# Altium schematic sheet Samyak_Sensors.SchDoc(Samyak_Sensors)
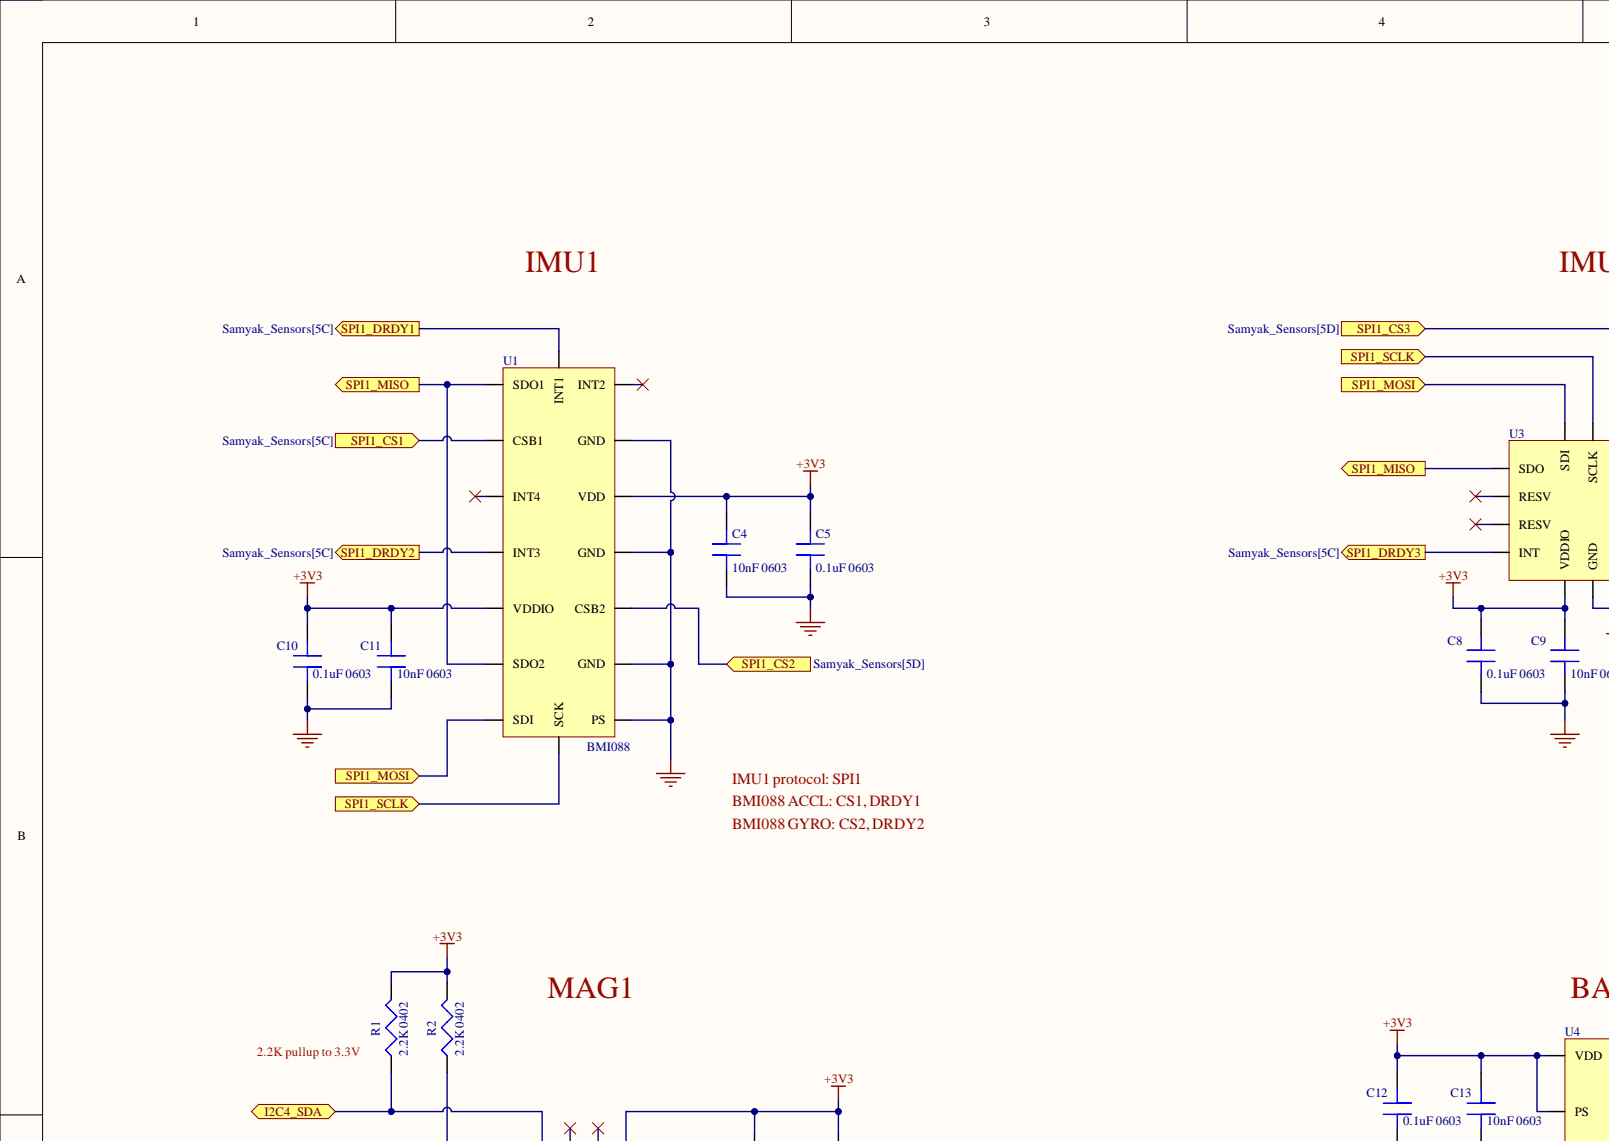
[diagram p0: Samyak_Sensors.SchDoc, top left]
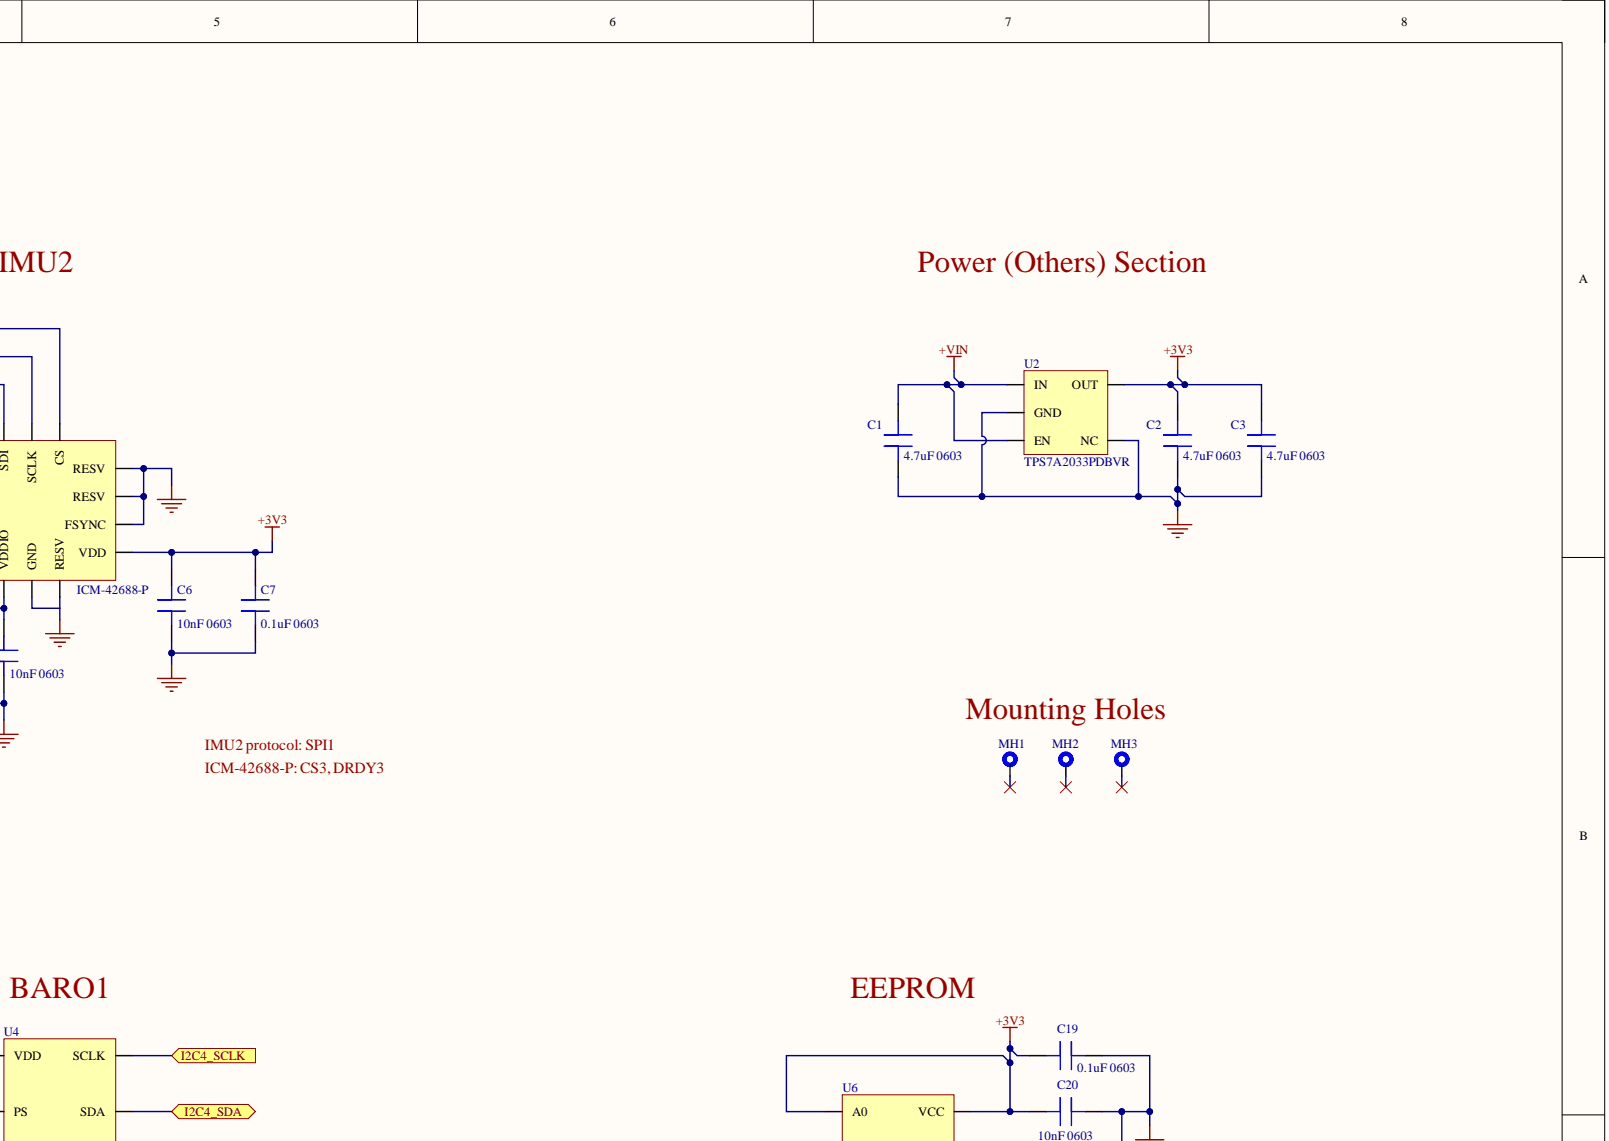
[diagram p1: Samyak_Sensors.SchDoc, top right]
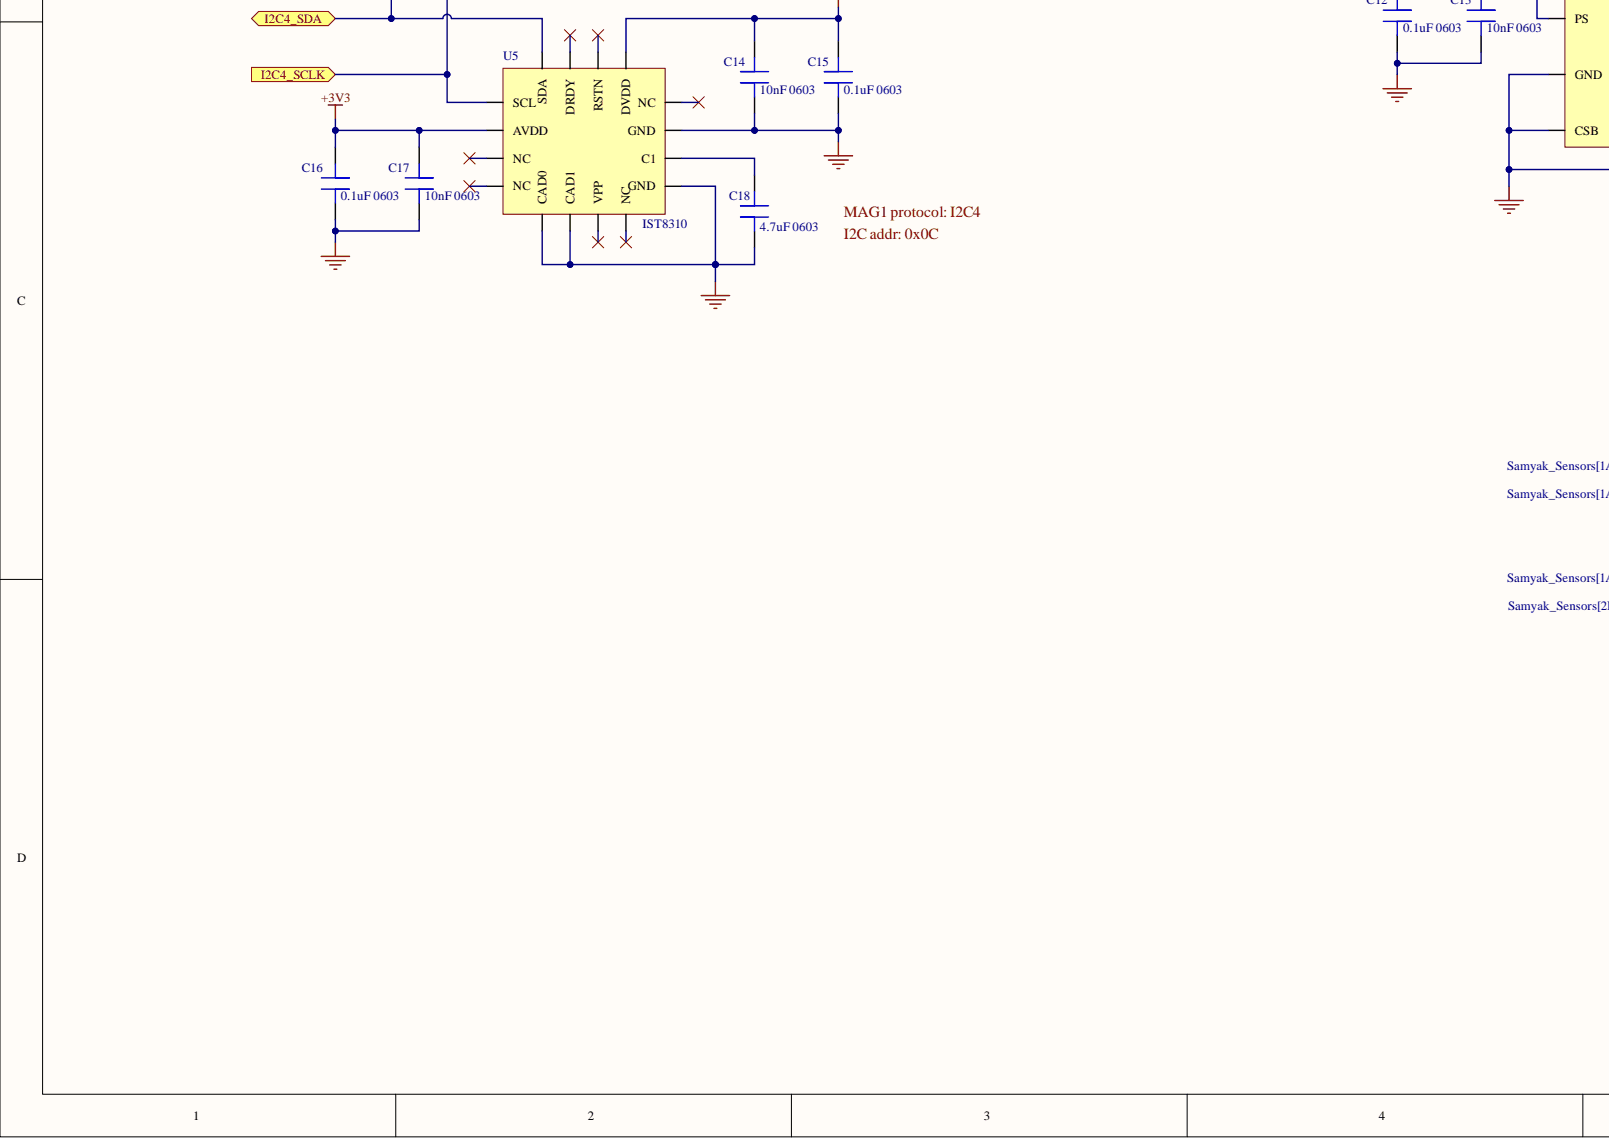
[diagram p2: Samyak_Sensors.SchDoc, bottom left]
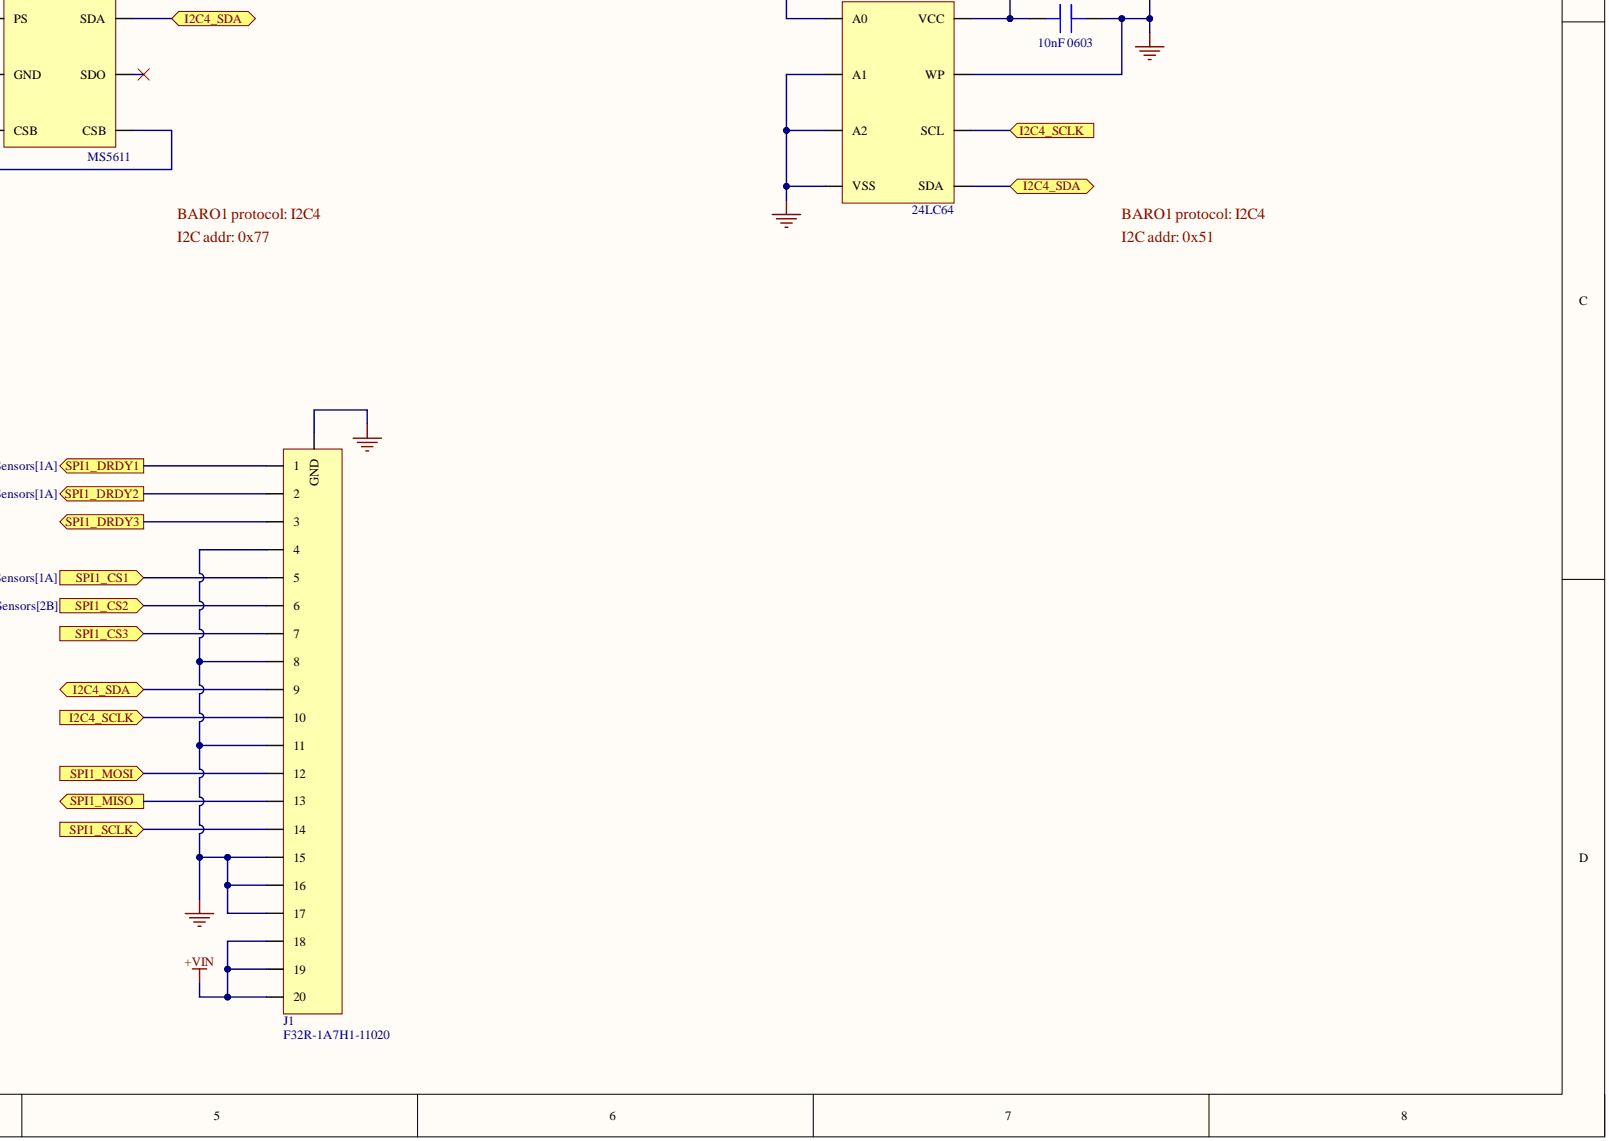
[diagram p3: Samyak_Sensors.SchDoc, bottom right]

## Parts
C1  4.7uF 0603  [GRM18]
C2  4.7uF 0603  [GRM18]
C3  4.7uF 0603  [GRM18]
C4  10nF 0603  Capacitance: 10nF  [C0603]
C5  0.1uF 0603  Case Code (Imperial): 0603  [C0603]
C6  10nF 0603  Capacitance: 10nF  [C0603]
C7  0.1uF 0603  Case Code (Imperial): 0603  [C0603]
C8  0.1uF 0603  [C0603]
C9  10nF 0603  Capacitance: 10nF  Case Code (Imperial): 0603  [C0603]
C10  0.1uF 0603  [C0603]
C11  10nF 0603  Capacitance: 10nF  Case Code (Imperial): 0603  [C0603]
C12  0.1uF 0603  [C0603]
C13  10nF 0603  Capacitance: 10nF  Case Code (Imperial): 0603  [C0603]
C14  10nF 0603  Capacitance: 10nF  [C0603]
C15  0.1uF 0603  Case Code (Imperial): 0603  [C0603]
C16  0.1uF 0603  [C0603]
C17  10nF 0603  Capacitance: 10nF  Case Code (Imperial): 0603  [C0603]
C18  4.7uF 0603  [GRM18]
C19  0.1uF 0603  Case Code (Imperial): 0603  [C0603]
C20  10nF 0603  Capacitance: 10nF  Case Code (Imperial): 0603  [C0603]
J1  F32R-1A7H1-11020  [F32R-1A7H1-11020]
MH1  M2 Hole NPTH  [M2_hole_NPTH]  (value not drawn)
MH2  M2 Hole NPTH  [M2_hole_NPTH]  (value not drawn)
MH3  M2 Hole NPTH  [M2_hole_NPTH]  (value not drawn)
R1  2.2K 0402  Case Code (Imperial): 0402  [R0402]
R2  2.2K 0402  Case Code (Imperial): 0402  [R0402]
U1  BMI088  [BMI088]
U2  TPS7A2033PDBVR  [TPS7A20]
U3  ICM-42688-P  [ICM-42688-P]
U4  MS5611  [MS5611]
U5  IST8310  [IST8310]
U6  24LC64  [24LC64]

## Ports
I2C4_SCLK, I2C4_SDA, SPI1_CS1, SPI1_CS2, SPI1_CS3, SPI1_DRDY1, SPI1_DRDY2, SPI1_DRDY3, SPI1_MISO, SPI1_MOSI, SPI1_SCLK

## Nets
+3V3: C2.2 C3.2 C4.2 C5.2 C6.2 C7.2 C8.2 C9.2 C10.2 C11.2 C12.2 C13.2 C14.2 C15.2 C16.2 C17.2 C19.2 C20.2 R1.2 R2.2 U1.3(VDD) U1.11(VDDIO) U2.5(OUT) U3.5(VDDIO) U3.8(VDD) U4.1(VDD) U4.2(PS) U5.2(AVDD) U5.13(DVDD) U6.1(A0) U6.8(VCC)
+VIN: C1.2 J1.18 J1.19 J1.20 U2.1(IN) U2.3(EN)
GND: C1.1 C2.1 C3.1 C4.1 C5.1 C6.1 C7.1 C8.1 C9.1 C10.1 C11.1 C12.1 C13.1 C14.1 C15.1 C16.1 C17.1 C18.1 C19.1 C20.1 J1.4 J1.8 J1.11 J1.15 J1.16 J1.17 J1.21(GND) U1.2(GND) U1.4(GND) U1.6(GND) U1.7(PS) U2.2(GND) U2.4(NC) U3.6(GND) U3.7(RESV) U3.9(FSYNC) U3.10(RESV) U3.11(RESV) U4.3(GND) U4.4(CSB) U4.5(CSB) U5.5(CAD0) U5.6(CAD1) U5.9(GND) U5.11(GND) U6.2(A1) U6.3(A2) U6.4(VSS) U6.7(WP)
I2C4_SCLK: J1.10 R2.1 U4.8(SCLK) U5.1(SCL) U6.6(SCL) ; port I2C4_SCLK
I2C4_SDA: J1.9 R1.1 U4.7(SDA) U5.16(SDA) U6.5(SDA) ; port I2C4_SDA
SPI1_CS1: J1.5 U1.14(CSB1) ; port SPI1_CS1
SPI1_CS2: J1.6 U1.5(CSB2) ; port SPI1_CS2
SPI1_CS3: J1.7 U3.12(CS) ; port SPI1_CS3
SPI1_DRDY1: J1.1 U1.16(INT1) ; port SPI1_DRDY1
SPI1_DRDY2: J1.2 U1.12(INT3) ; port SPI1_DRDY2
SPI1_DRDY3: J1.3 U3.4(INT) ; port SPI1_DRDY3
SPI1_MISO: J1.13 U1.10(SDO2) U1.15(SDO1) U3.1(SDO) ; port SPI1_MISO
SPI1_MOSI: J1.12 U1.9(SDI) U3.14(SDI) ; port SPI1_MOSI
SPI1_SCLK: J1.14 U1.8(SCK) U3.13(SCLK) ; port SPI1_SCLK
NetC18_2: C18.2 U5.10(C1)

Not connected: MH1 1; MH2 1; MH3 1; U1 1(INT2) 13(INT4); U3 2(RESV) 3(RESV); U4 6(SDO); U5 3(NC) 4(NC) 7(VPP) 8(NC) 12(NC) 14(RSTN) 15(DRDY)

## Library data of the parts
C1-C3 C18 = GRM188Z71C475KE21J: Multi-Layer Ceramic Capacitor 4.7uF 16VDC X7R 10% 0603 Paper T/R; Manufacturer: Murata; Category: Ceramic Capacitors; Component Kind: Standard; Library Name: Components.IntLib; Manufacturer Part Number: GRM188Z71C475KE21J; Pricing 1: 1=0.32000, 10=0.22200, 50=0.22200, 100=0.12600, 1000=0.07800, 10000=0.05600 (USD); RoHS: Yes; RoHS Compliant: Yes; Stock 1: 56562; Supplier 1: Mouser; Supplier Part Number 1: 81-GRM188Z71C475KE1J
C4 C6 C9 C11 C13 C14 C17 C20 = C0603C103K5RACTU: 10000pF ±10% 50V Ceramic Capacitor X7R 0603 (1608 Metric); Manufacturer: KEMET; Dissipation Factor: 2.5%; Case Code (Metric): 1608; Case/Package: 0603; Category: Ceramic Capacitors; Component Kind: Standard; ComponentLink1Description: https://datasheet.ciiva.com/7823/1732718-7823585.pdf?src-supplier=Element14; ComponentLink1URL: https://datasheet.ciiva.com/7823/1732718-7823585.pdf?src-supplier=Element14; ComponentLink2Description: https://datasheet.ciiva.com/5904/kem-c1002-x7r-smd-5904645.pdf?src-supplier=Farnell; ComponentLink2URL: https://datasheet.ciiva.com/5904/kem-c1002-x7r-smd-5904645.pdf?src-supplier=Farnell; ComponentLink3Description: https://datasheet.ciiva.com/18192/getdatasheetpartid-308803-18192662.pdf?src-supplier=Verical; ComponentLink3URL: https://datasheet.ciiva.com/18192/getdatasheetpartid-308803-18192662.pdf?src-supplier=Verical; ComponentLink4Description: https://datasheet.ciiva.com/39122/kem-c1002-x7r-smd-39122903.pdf?src-supplier=Onlinecomponents.com; ComponentLink4URL: https://datasheet.ciiva.com/39122/kem-c1002-x7r-smd-39122903.pdf?src-supplier=Onlinecomponents.com; ComponentLink5Description: https://datasheet.ciiva.com/7823/kem-c1002-x7r-smd-7823976.pdf?src-supplier=Element14; ComponentLink5URL: https://datasheet.ciiva.com/7823/kem-c1002-x7r-smd-7823976.pdf?src-supplier=Element14; ComponentLink6Description: https://datasheet.ciiva.com/7823/kem-c1002-x7r-smd-7823977.pdf?src-supplier=Element14; ComponentLink6URL: https://datasheet.ciiva.com/7823/kem-c1002-x7r-smd-7823977.pdf?src-supplier=Element14; ComponentLink7Description: https://datasheet.ciiva.com/7823/1918693-7823978.pdf?src-supplier=Element14; ComponentLink7URL: https://datasheet.ciiva.com/7823/1918693-7823978.pdf?src-supplier=Element14; ComponentLink8Description: https://datasheet.ciiva.com/14585/70095383-14585450.pdf?src-supplier=Allied+Electronics; ComponentLink8URL: https://datasheet.ciiva.com/14585/70095383-14585450.pdf?src-supplier=Allied+Electronics; ComponentLink9Description: https://datasheet.ciiva.com/18101/getdatasheetpartid-58468-18101898.pdf?src-supplier=Verical; ComponentLink9URL: https://datasheet.ciiva.com/18101/getdatasheetpartid-58468-18101898.pdf?src-supplier=Verical; ComponentLink10Description: https://datasheet.ciiva.com/5416/kem-c1002-x7r-smd-5416028.pdf?src-supplier=Mouser; ComponentLink10URL: https://datasheet.ciiva.com/5416/kem-c1002-x7r-smd-5416028.pdf?src-supplier=Mouser; ComponentLink11Description: https://datasheet.ciiva.com/28645/1851736-28645598.pdf?src-supplier=Element14; ComponentLink11URL: https://datasheet.ciiva.com/28645/1851736-28645598.pdf?src-supplier=Element14; Contact Plating: Tin,Matte; Depth: 0.8mm; Dielectric: X7R; Dielectric Material: Ceramic; Height: 0.8mm; Lead Pitch: 0.7mm; Length: 1.6mm; Library Name: Components.IntLib; Manufacturer Part Number: C0603C103K5RACTU; Max Operating Temperature: 125°C; Min Operating Temperature: -55°C; Mount: Surface Mount,PCB; Number of Pins: 2; Package Quantity: 4000; Packaging: Tape and Reel; Pricing 1: 1=0.10000, 10=0.07000, 50=0.03820, 100=0.03130, 500=0.02234, 1000=0.01755 (USD); Radiation Hardening: No; REACH SVHC: No SVHC; RoHS: Yes; Stock 1: 1389959; Supplier 1: Digi-Key; Supplier Part Number 1: 399-1091-1-ND; Termination: SMD/SMT; Thickness: 0.034inch; Tolerance: 10%; Voltage: 50V; Voltage Rating: 50V; Voltage Rating (DC): 50V; Width: 0.8mm
  C4 C6 C14: Case Code (Imperial): 0603
C5 C7 C8 C10 C12 C15 C16 C19 = C0603C104K5RACTU: 0.1µF ±10% 50V Ceramic Capacitor X7R 0603 (1608 Metric); Manufacturer: KEMET; Capacitance: 100nF; Dissipation Factor: 2.5%; Case Code (Metric): 1608; Case/Package: 0603; Category: Ceramic Capacitors; Component Kind: Standard; ComponentLink1Description: https://datasheet.ciiva.com/7823/kem-c1002-x7r-smd-7823591.pdf?src-supplier=Element14; ComponentLink1URL: https://datasheet.ciiva.com/7823/kem-c1002-x7r-smd-7823591.pdf?src-supplier=Element14; ComponentLink2Description: https://datasheet.ciiva.com/14602/70095383-14602583.pdf?src-supplier=Allied+Electronics; ComponentLink2URL: https://datasheet.ciiva.com/14602/70095383-14602583.pdf?src-supplier=Allied+Electronics; ComponentLink3Description: https://datasheet.ciiva.com/5999/kem-c1002-x7r-smd-5999400.pdf?src-supplier=Farnell; ComponentLink3URL: https://datasheet.ciiva.com/5999/kem-c1002-x7r-smd-5999400.pdf?src-supplier=Farnell; ComponentLink4Description: https://datasheet.ciiva.com/7823/kem-c1002-x7r-smd-7823592.pdf?src-supplier=Element14; ComponentLink4URL: https://datasheet.ciiva.com/7823/kem-c1002-x7r-smd-7823592.pdf?src-supplier=Element14; ComponentLink5Description: https://datasheet.ciiva.com/28645/1851736-28645640.pdf?src-supplier=Element14; ComponentLink5URL: https://datasheet.ciiva.com/28645/1851736-28645640.pdf?src-supplier=Element14; ComponentLink6Description: https://datasheet.ciiva.com/14585/70095383-14585209.pdf?src-supplier=Allied+Electronics; ComponentLink6URL: https://datasheet.ciiva.com/14585/70095383-14585209.pdf?src-supplier=Allied+Electronics; Contact Plating: Tin,Matte; Depth: 0.8mm; Dielectric: X7R; Dielectric Material: Ceramic; Height: 0.8mm; Lead Pitch: 0.7mm; Length: 0.063inch; Library Name: Components.IntLib; Manufacturer Part Number: C0603C104K5RACTU; Max Operating Temperature: 125°C; Min Operating Temperature: -55°C; Mount: Surface Mount; Number of Pins: 2; Package Quantity: 1; Packaging: Tape and Reel; Pricing 1: 1=0.12000, 10=0.08900, 50=0.04840, 100=0.03940, 500=0.02818, 1000=0.02214 (USD); Radiation Hardening: No; REACH SVHC: No SVHC; RoHS: Yes; RoHS Compliant: Yes; Series: SMD Comm X7R; Stock 1: 3160568; Supplier 1: Digi-Key; Supplier Part Number 1: 399-5089-1-ND; Termination: SMD/SMT; Thickness: 0.034inch; Tolerance: 10%; Voltage: 50V; Voltage Rating: 50V; Voltage Rating (DC): 50V; Width: 0.031inch
  C8 C10 C12 C16: Case Code (Imperial): 0603
J1 = F32R-1A7H1-11020: 20 Position FFC, FPC Connector Contacts, Bottom 0.020" (0.50mm) Surface Mount, Right Angle; Manufacturer: Amphenol ICC / FCI; Category: FFC / FPC; Component Kind: Standard; Library Name: Components.SchLib; Manufacturer Part Number: F32R-1A7H1-11020; Pricing: 1=0.73, 10=0.633, 25=0.578, 50=0.5442, 100=0.5215, 250=0.47616, 500=0.45348 (USD); RoHS: No; Stock: 27715; Supplier: Digi-Key; Supplier Part Number: 609-F32R-1A7H1-11020CT-ND
MH1-MH3 = M2_hole_NPTH: Component Kind: Standard; Library Name: Components.IntLib
R1 R2 = CRCW04022K20FKED: 2.2 kOhms ±1% 0.063W, 1/16W Chip Resistor 0402 (1005 Metric) Automotive AEC-Q200 Thick Film; Manufacturer: Vishay; Max Power Dissipation: 63mW; Temperature Coefficient: 100ppm/°C; Value: 2.2kR; Voltage Rating: 50V; Case Code (Metric): 1005; Case/Package: 0402; Category: Chip SMD Resistors; Component Kind: Standard; ComponentLink1Description: https://datasheet.ciiva.com/11811/0900766b80d98824-11811709.pdf?src-supplier=Rs; ComponentLink1URL: https://datasheet.ciiva.com/11811/0900766b80d98824-11811709.pdf?src-supplier=Rs; ComponentLink2Description: https://datasheet.ciiva.com/26316/fld-crcw-e3-data-en-26316650.pdf?src-supplier=Distrelec; ComponentLink2URL: https://datasheet.ciiva.com/26316/fld-crcw-e3-data-en-26316650.pdf?src-supplier=Distrelec; ComponentLink3Description: https://datasheet.ciiva.com/7777/1697337-7777228.pdf?src-supplier=Element14; ComponentLink3URL: https://datasheet.ciiva.com/7777/1697337-7777228.pdf?src-supplier=Element14; ComponentLink4Description: https://datasheet.ciiva.com/7777/1697337-7777227.pdf?src-supplier=Element14; ComponentLink4URL: https://datasheet.ciiva.com/7777/1697337-7777227.pdf?src-supplier=Element14; ComponentLink5Description: https://datasheet.ciiva.com/2464/dcrcwe3-2464486.pdf?src-supplier=Digi-Key; ComponentLink5URL: https://datasheet.ciiva.com/2464/dcrcwe3-2464486.pdf?src-supplier=Digi-Key; ComponentLink6Description: https://datasheet.ciiva.com/5541/dcrcwe3-109170-5541416.pdf?src-supplier=Mouser; ComponentLink6URL: https://datasheet.ciiva.com/5541/dcrcwe3-109170-5541416.pdf?src-supplier=Mouser; ComponentLink7Description: https://datasheet.ciiva.com/3256/dcrcwe3-3256341.pdf?src-supplier=Avnet; ComponentLink7URL: https://datasheet.ciiva.com/3256/dcrcwe3-3256341.pdf?src-supplier=Avnet; ComponentLink8Description: https://datasheet.ciiva.com/16209/dcrcwe3-16209157.pdf?src-supplier=Future+Electronics; ComponentLink8URL: https://datasheet.ciiva.com/16209/dcrcwe3-16209157.pdf?src-supplier=Future+Electronics; ComponentLink9Description: https://datasheet.ciiva.com/2464/dcrcwe3-2464487.pdf?src-supplier=Digi-Key; ComponentLink9URL: https://datasheet.ciiva.com/2464/dcrcwe3-2464487.pdf?src-supplier=Digi-Key; ComponentLink10Description: https://datasheet.ciiva.com/2464/dcrcwe3-2464488.pdf?src-supplier=Digi-Key; ComponentLink10URL: https://datasheet.ciiva.com/2464/dcrcwe3-2464488.pdf?src-supplier=Digi-Key; ComponentLink11Description: https://datasheet.ciiva.com/16778/70201119-16778943.pdf?src-supplier=Allied+Electronics; ComponentLink11URL: https://datasheet.ciiva.com/16778/70201119-16778943.pdf?src-supplier=Allied+Electronics; Construction: Rectangular; Contact Plating: Tin; Depth: 0.5mm; Features: Automotive AEC-Q200; Height: 0.35mm; Lead Free: Lead Free; Length: 1mm; Library Name: Components.IntLib; Manufacturer Part Number: CRCW04022K20FKED; Max Operating Temperature: 155°C; Military Standard: Not; Min Operating Temperature: -55°C; Number of Terminations: 2; Package Quantity: 10; Packaging: Tape and Reel; Power Rating: 63mW; Pricing: 1=0.1, 10=0.033, 50=0.0182, 100=0.0134, 500=0.00818, 1000=0.00602, 5000=0.00432 (USD); Radiation Hardening: No; Ratings: AEC-Q200; Reach SVHC Compliant: Unknown; Resistance Tolerance: 1%; Resistor Type: Thick Film; RoHS: Yes; RoHS Compliant: Yes; Series: CRCW; Stock: 1272851; Supplier: Digi-Key; Supplier Part Number: 541-2.20KLCT-ND; Technology: THICK FILM; Termination: SMD/SMT; Tolerance: 1%; Voltage Rating (DC): 50V; Weight: 2.3E-05oz; Width: 0.02inch
U1 = BMI088: Accelerometer, Gyroscope, 6 Axis Sensor I²C, SPI Output; Manufacturer: Bosch Tools; Category: Inertial Measurement Units (IMUs); Component Kind: Standard; ComponentLink1Description: https://ae-bst.resource.bosch.com/media/_tech/media/application_notes/BST-MIS-HS001.pdf?src-supplier=Digi-Key; ComponentLink1URL: https://ae-bst.resource.bosch.com/media/_tech/media/application_notes/BST-MIS-HS001.pdf?src-supplier=Digi-Key; ComponentLink2Description: https://ae-bst.resource.bosch.com/media/_tech/media/product_flyer/Bosch_Sensortec_Product_flyer_BMI088.pdf?src-supplier=Digi-Key; ComponentLink2URL: https://ae-bst.resource.bosch.com/media/_tech/media/product_flyer/Bosch_Sensortec_Product_flyer_BMI088.pdf?src-supplier=Digi-Key; Library Name: IC_chips.IntLib; Manufacturer Part Number: BMI088; Pricing 1: 1=7.7, 10=5.772, 25=5.1304, 50=4.8738, 100=4.7456, 500=3.97606, 1000=3.71954 (USD); RoHS: No; Stock 1: 13052; Supplier 1: Digi-Key; Supplier Part Number 1: 828-1082-1-ND
U2 = TPS7A2033PDBVR: IC REG LINEAR 3.3V 300MA SOT23-5; Manufacturer: Texas Instruments; Component Kind: Standard; Library Name: IC_chips.IntLib; Manufacturer Part Number: TPS7A2033PDBVR; Pricing 1: 1=0.46000, 10=0.39800, 25=0.37120, 100=0.27560, 250=0.23320, 500=0.22260, 1000=0.16430 (USD); RoHS: No; Stock 1: 2123; Supplier 1: Digi-Key; Supplier Part Number 1: 296-TPS7A2033PDBVRCT-ND
U3 = ICM-42688-P: Accelerometer, Gyroscope, 6 Axis Sensor Output; Manufacturer: TDK InvenSense; Category: Inertial Measurement Units (IMUs); Component Kind: Standard; ComponentLink1Description: https://media.digikey.com/pdf/Data%20Sheets/TDK%20PDFs/ICM-42688-P_DS_Rev1.2.pdf?src-supplier=Digi-Key; ComponentLink1URL: https://media.digikey.com/pdf/Data%20Sheets/TDK%20PDFs/ICM-42688-P_DS_Rev1.2.pdf?src-supplier=Digi-Key; Library Name: IC_chips.IntLib; Manufacturer Part Number: ICM-42688-P; Pricing 1: 1=3.72, 10=3.567 (USD); Reach SVHC Compliant: Yes; RoHS: No; Stock 1: 21464; Supplier 1: Digi-Key; Supplier Part Number 1: 1428-ICM-42688-PCT-ND
U4 = MS561101BA03-50: Pressure Sensor 0.15PSI ~ 17.4PSI (1kPa ~ 120kPa) Absolute 24 b 8-SMD; Manufacturer: TE Connectivity; Body Breadth: 3inch; Body Height: 1mm; Max Supply Voltage: 3.6V; Min Supply Voltage: 1.8V; Number of Bits: 24; Operating Current-Max: 12.5uA; Output Interface Type: I2C; Output Range: 0-3V; Output Type: DIGITAL VOLTAGE; Package Shape/Style: Rectangular; Pressure Range-Max: 17.404PSI; Pressure Range-Min: 0.145PSI; Response Time: 8220us; Sensor Type: PRESSURE SENSOR,PEIZORESISTIVE; Category: Pressure Sensors; Component Kind: Standard; ComponentLink1Description: https://www.te.com:443/usa-en/product-MS561101BA03-50.datasheet.pdf?src-supplier=IHS+Markit; ComponentLink1URL: https://www.te.com:443/usa-en/product-MS561101BA03-50.datasheet.pdf?src-supplier=IHS+Markit; ComponentLink2Description: http://www.farnell.com/datasheets/2917208.pdf?src-supplier=Farnell; ComponentLink2URL: http://www.farnell.com/datasheets/2917208.pdf?src-supplier=Farnell; ComponentLink3Description: http://www.farnell.com/datasheets/1756128.pdf?src-supplier=Newark; ComponentLink3URL: http://www.farnell.com/datasheets/1756128.pdf?src-supplier=Newark; ComponentLink4Description: https://www.te.com/commerce/DocumentDelivery/DDEController?Action=srchrtrv&DocNm=MS5611-01BA03&DocType=Data+Sheet&DocLang=English&src-supplier=Digi-Key; ComponentLink4URL: https://www.te.com/commerce/DocumentDelivery/DDEController?Action=srchrtrv&DocNm=MS5611-01BA03&DocType=Data+Sheet&DocLang=English&src-supplier=Digi-Key; Library Name: IC_chips.IntLib; Manufacturer Part Number: MS561101BA03-50; Max Operating Temperature: 85°C; Min Operating Temperature: -40°C; Mounting Technology: Surface Mount; Pricing 1: 1=11.59, 10=8.64, 50=8.64, 100=7.47, 1000=6.54, 10000=6.41 (USD); Reach SVHC Compliant: Yes; RoHS: Yes; RoHS Compliant: Yes; Stock 1: 9928; Supplier 1: Mouser; Supplier Part Number 1: 824-MS561101BA03-50; Termination: Solder
U5 = IST8310: 3D Magnetometer Sensor, 3.0x3.0x1.0mm3 , 16-pin LGA package; Component Kind: Standard; Library Name: IC_chips.SchLib
U6 = 24LC64T-E/ST: EEPROM Memory IC 64Kbit I²C 400 kHz 900 ns 8-TSSOP; Manufacturer: Microchip; Channels: 1; Clock Frequency: 400kHz; Data Retention Time-Min: 200; Density: 65536b; Endurance: 1000000Cycles; I2C Control Byte: 1010DDDR; Max Supply Current: 3uA; Max Supply Voltage: 5.5V; Memory Size: 8192; Memory Width: 8; Min Supply Voltage: 2.5V; Nominal Supply Voltage: 5V; Number of Words Code: 8K; Operating Mode: SYNCHRONOUS; Organization: 8KX8; Package Body Material: Plastic; Package Equivalence Code: TSSOP8,.25; Parallel/Serial: SERIAL; Power Supplies: 3/5V; Qualification Status: Not Qualified; Serial Bus Type: I2C; Standby Current-Max: 5uA; Time @ Peak Reflow Temperature-Max (s): 40s; Write Cycle Time-Max (tWC): 5ms; Write Protection: HARDWARE; Case/Package: TSSOP; Category: EEPROM; Component Kind: Standard; ComponentLink1Description: https://datasheet.ciiva.com:443/pdfs/VipMasterIC/IC/MCHP/MCHPS03670/MCHPS03670-1.pdf?src-supplier=IHS+Markit; ComponentLink1URL: https://datasheet.ciiva.com:443/pdfs/VipMasterIC/IC/MCHP/MCHPS03670/MCHPS03670-1.pdf?src-supplier=IHS+Markit; ComponentLink2Description: https://datasheet.ciiva.com/3186/21189r-3186775.pdf?src-supplier=Avnet; ComponentLink2URL: https://datasheet.ciiva.com/3186/21189r-3186775.pdf?src-supplier=Avnet; Height - Seated (Max): 1.2mm; JESD-30 Code: R-PDSO-G8; JESD-609 Code: e3; Length: 4.4mm; Library Name: IC_chips.IntLib; Manufacturer Part Number: 24LC64T-E/ST; Max Operating Temperature: 125°C; Memory Format: EEPROM; Min Operating Temperature: -40°C; Moisture Sensitivity Level: 1; Package Shape: Rectangular; Package Style: SMALL OUTLINE, THIN PROFILE, SHRINK PITCHMeter; Peak Reflow Temperature (Cel): 260°C; Pins: 8; Pricing 1: 1=0.64, 25=0.612 (USD); Reach SVHC Compliant: Yes; RoHS: Yes; RoHS Compliant: Yes; Stock 1: 25080; Supplier 1: Digi-Key; Supplier Part Number 1: 24LC64T-E/STCT-ND; Surface Mount: Yes; Technology: CMOS; Temperature Grade: AUTOMOTIVE; Terminal Finish: Tin; Terminal Form: Gull Wing; Terminal Pitch: 0.65mm; Terminal Position: DUAL; Width: 3mm
